# Altium schematic sheet PLL.SchDoc(PLL)
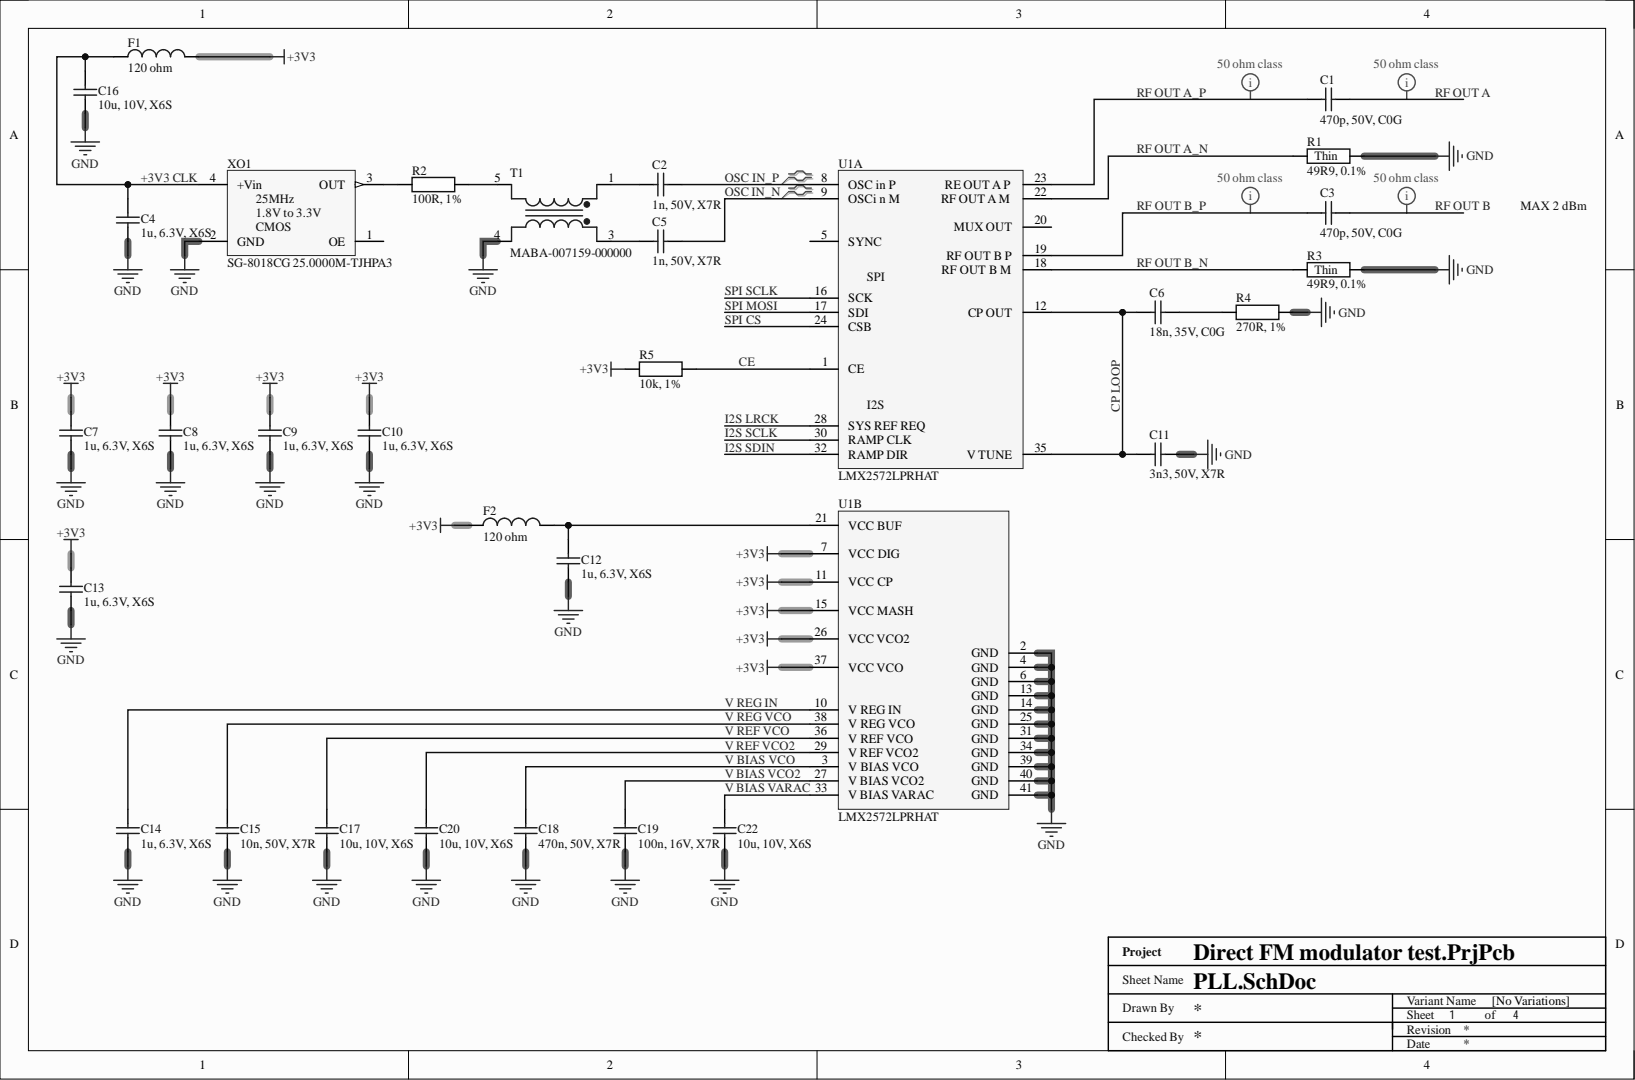
[diagram p0: PLL.SchDoc]

## Parts
C1  470p, 50V, C0G  [SMD0402]
C2  1n, 50V, X7R  [SMD0402]
C3  470p, 50V, C0G  [SMD0402]
C4  1u, 6.3V, X6S  [SMD0402]
C5  1n, 50V, X7R  [SMD0402]
C6  18n, 35V, C0G  [SMD0603]
C7  1u, 6.3V, X6S  [SMD0402]
C8  1u, 6.3V, X6S  [SMD0402]
C9  1u, 6.3V, X6S  [SMD0402]
C10  1u, 6.3V, X6S  [SMD0402]
C11  3n3, 50V, X7R  [SMD0603]
C12  1u, 6.3V, X6S  [SMD0402]
C13  1u, 6.3V, X6S  [SMD0402]
C14  1u, 6.3V, X6S  [SMD0402]
C15  10n, 50V, X7R  [SMD0402]
C16  10u, 10V, X6S  [SMD0603]
C17  10u, 10V, X6S  [SMD0603]
C18  470n, 50V, X7R  [SMD0603]
C19  100n, 16V, X7R  [SMD0402]
C20  10u, 10V, X6S  [SMD0603]
C22  10u, 10V, X6S  [SMD0603]
F1  120 ohm  [SMD0805 - R]
F2  120 ohm  [SMD0805 - R]
R1  49R9, 0.1%  [SMD0402 - R]
R2  100R, 1%  [SMD0402 - R]
R3  49R9, 0.1%  [SMD0402 - R]
R4  270R, 1%  [SMD0603 - R]
R5  10k, 1%  [SMD0603 - R]
T1  MABA-007159-000000  [MABA-007159-000000]
U1  LMX2572LPRHAT  [VQFN50P600X600X100_HS-41N]  (parts A,B)
XO1  SG-8018CG 25.0000M-TJHPA3  Freq: 25MHz  [XO 2.5x2.0mm]

## Nets
+3V3: C7.2 C8.2 C9.2 C10.2 C13.2 F1.2 F2.1 R5.2 U1.7(VCC_DIG) U1.11(VCC_CP) U1.15(VCC_MASH) U1.26(VCC_VCO2) U1.37(VCC_VCO)
GND: C4.1 C7.1 C8.1 C9.1 C10.1 C11.1 C12.1 C13.1 C14.1 C15.1 C16.1 C17.1 C18.1 C19.1 C20.1 C22.1 R1.2 R3.2 R4.1 T1.4 U1.2(GND) U1.4(GND) U1.6(GND) U1.13(GND) U1.14(GND) U1.25(GND) U1.31(GND) U1.34(GND) U1.39(GND) U1.40(GND) U1.41(GND) XO1.2(GND)
+3V3 CLK: C4.2 C16.2 F1.1 XO1.4(+Vin)
CE: R5.1 U1.1(CE)
CP LOOP: C6.1 C11.2 U1.12(CP_OUT) U1.35(V_TUNE)
I2S LRCK: U1.28(SYS_REF_REQ)
I2S SCLK: U1.30(RAMP_CLK)
I2S SDIN: U1.32(RAMP_DIR)
OSC IN_N: C5.2 U1.9(OSCi_n_M)
OSC IN_P: C2.2 U1.8(OSC_in_P)
RF OUT A: C1.2
RF OUT A_N: R1.1 U1.22(RF_OUT_A_M)
RF OUT A_P: C1.1 U1.23(RE_OUT_A_P)
RF OUT B: C3.2
RF OUT B_N: R3.1 U1.18(RF_OUT_B_M)
RF OUT B_P: C3.1 U1.19(RF_OUT_B_P)
SPI CS: U1.24(CSB)
SPI MOSI: U1.17(SDI)
SPI SCLK: U1.16(SCK)
V BIAS VARAC: C22.2 U1.33(V_BIAS_VARAC)
V BIAS VCO: C18.2 U1.3(V_BIAS_VCO)
V BIAS VCO2: C19.2 U1.27(V_BIAS_VCO2)
V REF VCO: C17.2 U1.36(V_REF_VCO)
V REF VCO2: C20.2 U1.29(V_REF_VCO2)
V REG IN: C14.2 U1.10(V_REG_IN)
V REG VCO: C15.2 U1.38(V_REG_VCO)
NetC2_1: C2.1 T1.1
NetC5_1: C5.1 T1.3
NetC6_2: C6.2 R4.2
NetC12_2: C12.2 F2.2 U1.21(VCC_BUF)
NetR2_1: R2.1 XO1.3(OUT)
NetR2_2: R2.2 T1.5

Not connected: U1 5(SYNC) 20(MUX_OUT); XO1 1(OE)

## Library data of the parts
C1 C3 = GCM1555C1H471FA16D: Cap Ceramic 470pF 50V C0G 1% Pad SMD 0402 125°C Automotive T/R; Manufacturer: Murata; Capacitance: 470p; Category: Ceramic Capacitors; Component Kind: Standard; Library Name: SMD0402Cap.IntLib; Manufacturer Part Number: GCM1555C1H471FA16D; Pricing 1: 1=0.12000, 10=0.06100, 50=0.06100, 100=0.04400, 1000=0.02700, 10000=0.01900 (USD); RoHS: Yes; Stock 1: 34290; Supplier 1: Mouser; Supplier 2: Farnell; Supplier Part Number 1: 81-GCM1555C1H471FA6D; Supplier Part Number 2: 3582659
C2 C5 = MC0402B102K500CT: MULTICOMP - MC0402B102K500CT - CAP, MLCC, X7R, 1NF, 50V, 0402; Capacitance Tolerance: ± 10%; Capacitor Terminals: SMD; Ceramic Capacitor Case: 0402 [1005 Metric]; Dielectric Characteristic: X7; External Depth: 1; External Length / Height: 0.5; No. of Pins: 2; Product Range: MC Series; SVHC: No SVHC (07-Jul-2017); Voltage Rating: 50; Automotive Qualification Standard: -; Capacitance: 1n; Capacitance Tolerance ±: ± 10%; Component Kind: Standard; ComponentLink1Description: http://www.farnell.com/datasheets/1901289.pdf; ComponentLink1URL: http://www.farnell.com/datasheets/1901289.pdf; External Width: 0.5; Library Name: SMD0402Cap.IntLib; Manufacturer: MULTICOMP; Manufacturer Part Number: MC0402B102K500CT; MSL: -; Operating Temperature Max: 125; Operating Temperature Min: -55; Operating Temperature Range: -55°C to +125°C<!--FANDB--><div class="features-and-benefits-container"><div class="features-and-benefits-desc-container"><span class="features-and-benefits-container-desc">SMD Multilayer Ceramic Capacitor, 0402 [1005 Metric], 1000 pF, 50 V, ± 10%, X7R, MC Series</span></div><div class="features-and-benefits-description"><span class="features-benefits-value">The Multicomp MC series is general purpose MLCC capacitors with X7R dielectric material which provides high electrical precision, stability and reliability. This MLCC capacitor consists of a conducting material and electrodes with high density and high efficiency ceramic condensers.</span></div><div class="features-and-benefits-list"><ul class="features-and-benefits-list-list"><li class="features-and-benefits-list-item">Small size with higher capacitance values</li><li class="features-and-benefits-list-item">0201, 0402, 0603, 0805, 1206, 1210, 1812 case sizes available</li><li class="features-and-benefits-list-item">Capacitance value ranging from 100pF to 47?F</li><li class="features-and-benefits-list-item">Capacitor with lead free termination (pure Tin)</li><li class="features-and-benefits-list-item">High electrical precision, stability and reliability</li><li class="features-and-benefits-list-item">Rated voltage of 6.3V, 10V, 16V, 25V, 50V, 100V</li><li class="features-and-benefits-list-item">Operating temperature of -55°C to +125°C</li><li class="features-and-benefits-list-item">Available in ±5%, ±10% and ±20; Packaging: Cut Tape; Pricing 1: 10=0,0036, 100=0,0032, 500=0,0029, 2500=0,0021, 5000=0,0018 (GBP); RoHS: YES; Stock 1: 83283; Supplier 1: Farnell; Supplier Part Number 1: 1758991
C4 C7-C10 C12-C14 = GRT155C80J105KE01D: MURATA - GRT155C80J105KE01D - CAP, MLCC, AECQ200, X6S, 1UF, 6.3V, 0402; Automotive Qualification Standard: AEC-Q200; Capacitance Tolerance: ± 10%; Ceramic Capacitor Case: 0402 [1005 Metric]; Dielectric Characteristic: X6; Product Range: GRT Series; SVHC: No SVHC (20-Jun-2016)<!--FANDB--><div class="features-and-benefits-container"><div class="features-and-benefits-description"><span class="features-benefits-value">The GRT155C80J105KE01D from Murata is a GRT series AEC-Q200 automotive-grade monolithic ceramic capacitor. This capacitor meets the AEC-Q200 reliability test standard requirements established by the Automotive Electronics Council. GRT series MLCC capacitors are classified into temperature compensating and high dielectric constant types depending on the change in electrostatic capacitance due to change in temperature. The temperature compensating capacitors feature a small rate of change in electrostatic capacitance as the temperature changes and are used for applications such as filters and high frequency circuit matching. The high dielectric constant capacitors use materials with a high dielectric constant and feature a large electrostatic capacitance. It is designed for use in car multimedia, car interior, car comfort application and general electronic equipment. It is not appropriate for use in applications critical to passenger safety and car driving function (ABS, AIRBAG etc).</span></div><div class="features-and-benefits-list"><ul class="features-and-benefits-list-list"><li class="features-and-benefits-list-item">AEC-Q200 automotive grade qualified</li><li class="features-and-benefits-list-item">Tin plated external electrodes, excellent solderability</li><li class="features-and-benefits-list-item">Capacitance value is 1µF</li><li class="features-and-benefits-list-item">Capacitance tolerance is ±10%</li><li class="features-and-benefits-list-item">Capacitor case size is 0402 [1005 Metric]</li><li class="features-and-benefits-list-item">X6S dielectric material</li><li class="features-and-benefits-list-item">Operating temperature range from -55°C to 105°C</li><li class="features-and-benefits-list-item">Voltage rating is 6.3; Voltage Rating: 6.3; Capacitance: 1u; Component Kind: Standard; ComponentLink1Description: http://www.farnell.com/datasheets/2165909.pdf; ComponentLink1URL: http://www.farnell.com/datasheets/2165909.pdf; Library Name: SMD0402Cap.IntLib; Manufacturer: MURATA; Manufacturer Part Number: GRT155C80J105KE01D; MSL: MSL 1 - Unlimited; Operating Temperature Max: 105; Operating Temperature Min: -55; Packaging: Cut Tape; Pricing 1: 10=0,0194, 100=0,0149, 500=0,0108, 2500=0,0079, 5000=0,0069, 10000=0,0062, 50000=0,0048, 100000=0,0047 (GBP); RoHS: YES; Stock 1: 19999; Supplier 1: Farnell; Supplier Part Number 1: 2672103
C6 = C1608C0G1V183J080AC: Cap Ceramic 0.018uF 35V C0G 5% Pad SMD 0603 125C T/R; Manufacturer: TDK; Capacitance: 18n; Case Code (Imperial): 0603; Case Code (Metric): 1608; Case/Package: 0603; Category: Ceramic Capacitors; Component Kind: Standard; ComponentLink1Description: https://product.tdk.com/info/en/catalog/spec/mlccspec_commercial_general_midvoltage_en.pdf?src-supplier=Digi-Key; ComponentLink1URL: https://product.tdk.com/info/en/catalog/spec/mlccspec_commercial_general_midvoltage_en.pdf?src-supplier=Digi-Key; Dielectric Material: Ceramic; Lead Free: Lead Free; Length: 0.063inch; Library Name: 0603Capacitors.SchLib; Manufacturer Part Number: C1608C0G1V183J080AC; Max Operating Temperature: 125°C; Min Operating Temperature: -55°C; Mount: Surface Mount; Packaging: Tape and Reel; Pricing 1: 1=0.37000, 10=0.16800, 50=0.16800, 100=0.14300, 1000=0.08800, 10000=0.06600 (USD); RoHS: Yes; RoHS Compliant: Yes; Stock 1: 3185; Supplier 1: Mouser; Supplier 2: Farnell; Supplier 3: Digi-Key; Supplier 4: Newark; Supplier Part Number 1: 810-C1608C0G1V183JAC; Supplier Part Number 2: 3416084; Supplier Part Number 3: 445-174558-1-ND; Supplier Part Number 4: 71AH3230; Thickness: 0.035inch; Tolerance: 5%; Voltage Rating: 35V; Voltage Rating (DC): 35V; Width: 0.031inch
C11 = 0603B332K500CT: WALSIN - 0603B332K500CT - CAPACITOR, MLCC, X7R, 3300PF, 50V, 0603; Capacitance Tolerance: ± 10%; Ceramic Capacitor Case: 0603 [1608 Metric]; Dielectric Characteristic: X7; Voltage Rating: 50; Automotive Qualification Standard: -; Capacitance: 3n3; Component Kind: Standard; ComponentLink1Description: http://www.farnell.com/datasheets/2340522.pdf; ComponentLink1URL: http://www.farnell.com/datasheets/2340522.pdf; Library Name: SMD0603Cap.IntLib; Manufacturer: WALSIN; Manufacturer Part Number: 0603B332K500CT; MSL: MSL 1 - Unlimited<!--FANDB--><div class="features-and-benefits-container"><div class="features-and-benefits-desc-container"><span class="features-and-benefits-container-desc">SMD Multilayer Ceramic Capacitor, 0603 [1608 Metric], 3300 pF, 50 V, ± 10%, X7R</span></div><div class="features-and-benefits-description"><span class="features-benefits-value">The general purpose series capacitors from Walsin are X7R dielectric multilayer ceramic chip capacitors (MLCC) that provides high electrical precision, stability and reliability. These MLCC capacitors consist of a conducting material and electrodes. The high density and high efficiency ceramic condensers are used to manufacture chip type miniaturized MLCC capacitors.</span></div><div class="features-and-benefits-list"><ul class="features-and-benefits-list-list"><li class="features-and-benefits-list-item">Capacitance values ranges from 100pF to 47?F</li><li class="features-and-benefits-list-item">EIA 0201, 0402, 0603, 0805, 1206, 1210, 1812 case sizes available</li><li class="features-and-benefits-list-item">Available voltage ratings are 6.3V, 10V, 16V, 25V, 35V, 50V, 100V</li><li class="features-and-benefits-list-item">Operating temperature range from -55°C to 125°C</li><li class="features-and-benefits-list-item">Available in ±5%, ±10%, ±20; Operating Temperature Max: 125; Operating Temperature Min: -55; Packaging: Cut Tape; Pricing 1: 10=0,0068, 100=0,0053, 500=0,0038, 1000=0,0031, 2000=0,0027 (GBP); Product Range: -; RoHS: YES; Stock 1: 10797; Supplier 1: Farnell; Supplier 2: Digi-Key; Supplier Part Number 1: 2496853; Supplier Part Number 2: BC1249TR-ND
C15 = MC0402B103K500CT: MULTICOMP - MC0402B103K500CT - CAP, MLCC, X7R, 0.01UF, 50V, 0402; Capacitance Tolerance: ± 10%; Ceramic Capacitor Case: 0402 [1005 Metric]; Dielectric Characteristic: X7; Product Range: MC Series; SVHC: No SVHC (12-Jan-2017)<!--FANDB--><div class="features-and-benefits-container"><div class="features-and-benefits-desc-container"><span class="features-and-benefits-container-desc">SMD Multilayer Ceramic Capacitor, 0402 [1005 Metric], 0.01 µF, 50 V, ± 10%, X7R, MC Series</span></div><div class="features-and-benefits-description"><span class="features-benefits-value">The Multicomp MC series are general purpose MLCC (Multilayer Ceramic Capacitor) capacitors with X7R dielectric material which provides high electrical precision, stability and reliability. These MLCC capacitors consists of a conducting material and electrodes with high density and high efficiency ceramic condensers.</span></div><div class="features-and-benefits-list"><ul class="features-and-benefits-list-list"><li class="features-and-benefits-list-item">Small size with higher capacitance values</li><li class="features-and-benefits-list-item">0201, 0402, 0603, 0805, 1206, 1210, 1812 case sizes available</li><li class="features-and-benefits-list-item">Capacitance value ranging from 100pF to 47?F</li><li class="features-and-benefits-list-item">Cu/Ni/Sn termination</li><li class="features-and-benefits-list-item">High electrical precision, stability and reliability</li><li class="features-and-benefits-list-item">Available in 6.3V, 10V, 16V, 25V, 50V and 100V rated voltages</li><li class="features-and-benefits-list-item">Operating temperature of -55°C to +125°C</li><li class="features-and-benefits-list-item">Available in ±5%, ±10% and ±20% tolerances</li><li class="features-and-benefits-list-item">Capacitance characteristic of ±15; Voltage Rating: 50; Automotive Qualification Standard: -; Capacitance: 10n; Component Kind: Standard; ComponentLink1Description: http://www.farnell.com/datasheets/2117933.pdf; ComponentLink1URL: http://www.farnell.com/datasheets/2117933.pdf; Library Name: SMD0402Cap.IntLib; Manufacturer: MULTICOMP; Manufacturer Part Number: MC0402B103K500CT; MSL: MSL 1 - Unlimited; Operating Temperature Max: 125; Operating Temperature Min: -55; Packaging: Cut Tape; Pricing 1: 10=0,0043, 100=0,0039, 500=0,0035, 2500=0,0021, 5000=0,0017 (GBP); RoHS: YES; Stock 1: 29387; Supplier 1: Farnell; Supplier Part Number 1: 2627340
C16 C17 C20 C22 = GRT188C81A106ME13D: Cap Ceramic 10uF 10V X6S 20% Pad SMD 0603 105C Automotive T/R; Manufacturer: Murata; Capacitance: 10uF; Case Code (Imperial): 0603; Case Code (Metric): 1608; Case/Package: 0603; Category: Ceramic Capacitors; Component Kind: Standard; ComponentLink1Description: http://search.murata.co.jp/Ceramy/image/img/A01X/G101/ENG/GRT188C81A106ME13-01.pdf?src-supplier=Digi-Key; ComponentLink1URL: http://search.murata.co.jp/Ceramy/image/img/A01X/G101/ENG/GRT188C81A106ME13-01.pdf?src-supplier=Digi-Key; Length: 0.063inch; Library Name: 0603Capacitors.SchLib; Manufacturer Part Number: GRT188C81A106ME13D; Max Operating Temperature: 105°C; Min Operating Temperature: -55°C; Mount: Surface Mount; Packaging: Tape and Reel; Pricing 1: 1=0.41000, 10=0.20100, 50=0.20100, 100=0.17200, 1000=0.10900, 10000=0.09700 (USD); Ratings: AEC-Q200; RoHS: No; Stock 1: 1862; Supplier 1: Mouser; Supplier 2: Farnell; Supplier 3: Digi-Key; Supplier Part Number 1: 81-GRT188C81A106ME3D; Supplier Part Number 2: 3582904; Supplier Part Number 3: 490-12283-6-ND; Thickness: 0.035inch; Tolerance: 20%; Voltage Rating: 10V; Width: 0.031inch
C18 = C1608X7R1H474K080AC: TDK - C1608X7R1H474K080AC - SMD Multilayer Ceramic Capacitor, 0.47 µF, 50 V, 0603 [1608 Metric], ± 10%, X7R, C Series TDK; Capacitance: 470n; Case Code (Imperial): 0603; Case Code (Metric): 1608; Case/Package: 0603; Category: Ceramic Capacitors; Component Kind: Standard; ComponentLink1Description: https://datasheet.ciiva.com/28693/1735394-28693461.pdf?src-supplier=Element14; ComponentLink1URL: https://datasheet.ciiva.com/28693/1735394-28693461.pdf?src-supplier=Element14; ComponentLink2Description: https://datasheet.ciiva.com/6290/tdkmlcccaprange-6290870.pdf?src-supplier=Digi-Key; ComponentLink2URL: https://datasheet.ciiva.com/6290/tdkmlcccaprange-6290870.pdf?src-supplier=Digi-Key; ComponentLink3Description: https://datasheet.ciiva.com/22718/1904212-22718022.pdf?src-supplier=Element14; ComponentLink3URL: https://datasheet.ciiva.com/22718/1904212-22718022.pdf?src-supplier=Element14; ComponentLink4Description: https://datasheet.ciiva.com/28451/1904212-28451367.pdf?src-supplier=Element14; ComponentLink4URL: https://datasheet.ciiva.com/28451/1904212-28451367.pdf?src-supplier=Element14; ComponentLink5Description: https://datasheet.ciiva.com/6290/tdkmlcccaprange-6290878.pdf?src-supplier=Digi-Key; ComponentLink5URL: https://datasheet.ciiva.com/6290/tdkmlcccaprange-6290878.pdf?src-supplier=Digi-Key; ComponentLink6Description: https://datasheet.ciiva.com/6290/tdkmlcccaprange-6290874.pdf?src-supplier=Digi-Key; ComponentLink6URL: https://datasheet.ciiva.com/6290/tdkmlcccaprange-6290874.pdf?src-supplier=Digi-Key; ComponentLink7Description: https://datasheet.ciiva.com/17487/e412-c-17487386.pdf?src-supplier=Avnet+Europe; ComponentLink7URL: https://datasheet.ciiva.com/17487/e412-c-17487386.pdf?src-supplier=Avnet+Europe; ComponentLink8Description: https://datasheet.ciiva.com/16427/e412-c-16427759.pdf?src-supplier=Future+Electronics; ComponentLink8URL: https://datasheet.ciiva.com/16427/e412-c-16427759.pdf?src-supplier=Future+Electronics; Composition: Ceramic; Depth: 0.8mm; Dielectric: X7R; Dielectric Material: Ceramic; Height: 0.8mm; Lead Free: Lead Free; Length: 1.6mm; Library Name: SMD0603Cap.IntLib; Manufacturer: TDK; Manufacturer Part Number: C1608X7R1H474K080AC; Max Operating Temperature: 125°C; Min Operating Temperature: -55°C; Mount: Surface Mount; Package Quantity: 4000; Packaging: Tape and Reel; Pricing 1: 1=0,28000, 10=0,14200, 100=0,09100, 500=0,07500, 1000=0,06100, 4000=0,04900 (USD); Radiation Hardening: No; REACH SVHC: No SVHC; RoHS: Yes; Stock 1: 163800; Supplier 1: Mouser; Supplier 2: Farnell; Supplier 3: Digi-Key; Supplier Part Number 1: 810-C1608X7R1H474K; Supplier Part Number 2: 2522012; Supplier Part Number 3: 445-5952-1-ND; Termination: SMD/SMT; Thickness: 0.037inch; Tolerance: 10%; Voltage: 50V; Voltage Rating: 50V; Voltage Rating (DC): 50V; Weight: 7.1E-05oz; Width: 0.031inch
C19 = MC0402B104K160CT: MULTICOMP - MC0402B104K160CT - CAP, MLCC, X7R, 100NF, 16V, 0402; Capacitance Tolerance: ± 10%; Capacitor Terminals: SMD; Ceramic Capacitor Case: 0402 [1005 Metric]; Dielectric Characteristic: X7; External Depth: 1; External Length / Height: 0.5; No. of Pins: 2; Product Range: MC Series; SVHC: No SVHC (07-Jul-2017); Voltage Rating: 16; Automotive Qualification Standard: -; Capacitance: 100n; Capacitance Tolerance ±: ± 10%; Component Kind: Standard; ComponentLink1Description: http://www.farnell.com/datasheets/1901289.pdf; ComponentLink1URL: http://www.farnell.com/datasheets/1901289.pdf; External Width: 0.5; Library Name: SMD0402Cap.IntLib; Manufacturer: MULTICOMP; Manufacturer Part Number: MC0402B104K160CT; MSL: -; Operating Temperature Max: 125; Operating Temperature Min: -55; Operating Temperature Range: -55°C to +125°C<!--FANDB--><div class="features-and-benefits-container"><div class="features-and-benefits-desc-container"><span class="features-and-benefits-container-desc">SMD Multilayer Ceramic Capacitor, 0402 [1005 Metric], 0.1 µF, 16 V, ± 10%, X7R, MC Series</span></div><div class="features-and-benefits-description"><span class="features-benefits-value">The Multicomp MC series is general purpose MLCC capacitors with X7R dielectric material which provides high electrical precision, stability and reliability. This MLCC capacitor consists of a conducting material and electrodes with high density and high efficiency ceramic condensers.</span></div><div class="features-and-benefits-list"><ul class="features-and-benefits-list-list"><li class="features-and-benefits-list-item">Small size with higher capacitance values</li><li class="features-and-benefits-list-item">0201, 0402, 0603, 0805, 1206, 1210, 1812 case sizes available</li><li class="features-and-benefits-list-item">Capacitance value ranging from 100pF to 47?F</li><li class="features-and-benefits-list-item">Capacitor with lead free termination (pure Tin)</li><li class="features-and-benefits-list-item">High electrical precision, stability and reliability</li><li class="features-and-benefits-list-item">Rated voltage of 6.3V, 10V, 16V, 25V, 50V, 100V</li><li class="features-and-benefits-list-item">Operating temperature of -55°C to +125°C</li><li class="features-and-benefits-list-item">Available in ±5%, ±10% and ±20; Packaging: Cut Tape; Pricing 1: 10=0,0043, 100=0,0039, 500=0,0035, 2500=0,0021, 5000=0,0017 (GBP); RoHS: YES; Stock 1: 315490; Supplier 1: Farnell; Supplier Part Number 1: 1758896
F1 F2 = 742792023: WURTH ELEKTRONIK - 742792023 - FERRITE BEAD, 0.03OHM, 3A, 0805; DC Current Rating: 3; DC Resistance Max: 0.03; Ferrite Case Style: 0805 [2012 Metric]; Ferrite Mounting: SMD; Impedance @ 100MHz: 120; Impedance Tolerance: ± 25%; Resistance: 0.03ohm<!--FANDB--><div class="features-and-benefits-container"><div class="features-and-benefits-description"><span class="features-benefits-value">The 742792023 is a SMD EMI Suppression Ferrite Bead with 0805 case and reliable Ni-Sn electrodes. It can be put directly on the printed circuit board. It offers excellent anti-EMI properties and low DC resistance. Placed very close next to the interference source even with smallest size 0402, maximal impedance at 1000; Automotive Qualification Standard: -; Component Kind: Standard; ComponentLink1Description: http://www.farnell.com/datasheets/1695980.pdf; ComponentLink1URL: http://www.farnell.com/datasheets/1695980.pdf; ComponentLink2Description: http://www.farnell.com/cad/1670054.zip; ComponentLink2URL: http://www.farnell.com/cad/1670054.zip; Library Name: Lib1.IntLib; Manufacturer: WURTH ELEKTRONIK; Manufacturer Part Number: 742792023; Packaging: Cut Tape; Pricing 1: 1=0,123, 50=0,112, 100=0,103, 500=0,0937, 1000=0,0877, 2000=0,0846 (GBP); Product Range: -; RoHS: YES; Stock 1: 4283; Supplier 1: Mouser; Supplier 2: Farnell; Supplier 3: Digi-Key; Supplier Part Number 1: 710-742792023; Supplier Part Number 2: 1635732; Supplier Part Number 3: 732-1613-1-ND
R1 R3 = AT0402BRD0749R9L: Thin Film Resistors - SMD 49.9 Ohms 0.1% Tol. AEC-Q200; Brand: Yageo; CNHTS: 8533211000; MXHTS: 85332101; Power Rating: 62.5 mW (1/16 W); TARIC: 8533210000; Temperature Coefficient: 25 PPM / C; Voltage Rating: 50 V; Case Code - in: 0402; Case Code - mm: 1005; Category: Thin Film Resistors - SMD; Component Kind: Standard; ComponentLink1Description: http://www.mouser.com/datasheet/2/447/PYu-AT_51_RoHS_L_5-1222714.pdf; ComponentLink1URL: http://www.mouser.com/datasheet/2/447/PYu-AT_51_RoHS_L_5-1222714.pdf; Diameter: -; Features: -; Height: 0.3 mm; HTS Code: 8533210030; Length: 1 mm; Library Name: SMD0402Res.IntLib; Manufacturer: Yageo; Manufacturer Part Number: AT0402BRD0749R9L; Maximum Operating Temperature: + 125 C; Minimum Operating Temperature: - 55 C; Minimum Order Quantity: 1; Mounting Style: PCB Mount; Operating Temperature Range: - 55 C to + 125 C; Package / Case: 0402 (1005 metric); Packaging: Cut Tape; Pricing 1: 1=0.594, 10=0.346, 100=0.139, 1000=0.106, 2500=0.081, 10000=0.079, 20000=0.077 (EUR); Product: Thin Film Resistors SMD; Product Type: Thin Film Resistors; Qualification: AEC-Q200; Reeling: True; Resistance: 49.9 Ohms; RoHS: RoHS Compliant; RoHS - Mouser: Y; Series: AT; Standard Pack Qty: 10000; Stock 1: 9662; Subcategory: Resistors; Supplier 1: Mouser; Supplier Part Number 1: 603-AT0402BRD0749R9L; Termination Style: SMD/SMT; Tolerance: 0.1 %; Type: High Precision High Stability Chip Resistors; Width: 0.5 mm
R2 = RC0402FR-07100RL: YAGEO - RC0402FR-07100RL - RES, 100R, 1%, 0.0625W, 0402, THICK FILM; Power Rating: 62.5; Product Range: RC Series; Reel Quantity: 10000<!--FANDB--><div class="features-and-benefits-container"><div class="features-and-benefits-desc-container"><span class="features-and-benefits-container-desc">SMD Chip Resistor, 0402 [1005 Metric], 100 ohm, RC Series, 50 V, Thick Film, 62.5 mW</span></div><div class="features-and-benefits-description"><span class="features-benefits-value">The Yageo RC_L series resistors are general purpose thick film chip resistors with lead free terminations made by thick film process. The resistance range includes E24 and E96 decade values from 1ohm to 100Mohm. These resistors are constructed on top of a high grade ceramic body.</span></div><div class="features-and-benefits-list"><ul class="features-and-benefits-list-list"><li class="features-and-benefits-list-item">EIA 0075, 0100, 0201, 0402, 0603, 0805, 1206, 1210, 1218, 2010, 2512 case sizes available</li><li class="features-and-benefits-list-item">Small chip sizes save PCB space</li><li class="features-and-benefits-list-item">Rated voltage range of 10V to 200V</li><li class="features-and-benefits-list-item">Maximum power rating of 2W</li><li class="features-and-benefits-list-item">Available in ±0.1%, ±0.5%, ±1% and ±5% tolerance</li><li class="features-and-benefits-list-item">High component and equipment reliability</li><li class="features-and-benefits-list-item">Forbidden materials are not used in products/production</li><li class="features-and-benefits-list-item">-55°C to 155°C temperature range for RC0402 to RC2512 and -55°C to 125°C for RC0075 to RC0201; Resistance: 100; Resistor Case Style: 0402 [1005 Metric]; SVHC: No SVHC (27-Jun-2018); Temperature Coefficient: ± 100; Voltage Rating: 50; Automotive Qualification Standard: -; Component Kind: Standard; ComponentLink1Description: http://www.farnell.com/datasheets/2167052.pdf; ComponentLink1URL: http://www.farnell.com/datasheets/2167052.pdf; Library Name: SMD0402Res.IntLib; Manufacturer: YAGEO; Manufacturer Part Number: RC0402FR-07100RL; MSL: MSL 1 - Unlimited; Operating Temperature Max: 155; Operating Temperature Min: -55; Pricing 1: 10=0.385, 100=0.38, 500=0.285, 2500=0.144, 5000=0.121 (EUR); Resistance Tolerance: ± 1%; Resistor Element Material: Thick Film; RoHS: YES; Stock 1: 260492; Supplier 1: Farnell; Supplier 2: Mouser; Supplier Part Number 1: 9239111; Supplier Part Number 2: 603-RC0402FR-07100RL
R4 = WR06X2700FTL: WALSIN - WR06X2700FTL - RES, THICK FILM, 270R, 1%, 75V, 0603; Power Rating: 100; Product Range: WR06 Series; Resistor Case Style: 0603 [1608 Metric]; Temperature Coefficient: ± 100; Voltage Rating: 75; Automotive Qualification Standard: -; Component Kind: Standard; ComponentLink1Description: http://www.farnell.com/datasheets/1960319.pdf; ComponentLink1URL: http://www.farnell.com/datasheets/1960319.pdf; Library Name: SMD0603Res.IntLib; Manufacturer: WALSIN; Manufacturer Part Number: WR06X2700FTL; MSL: MSL 2 - 1 year<!--FANDB--><div class="features-and-benefits-container"><div class="features-and-benefits-desc-container"><span class="features-and-benefits-container-desc">SMD Chip Resistor, 270 ohm, 75 V, 0603 [1608 Metric], 100 mW, ± 1%, WR06 Series</span></div><div class="features-and-benefits-description"><span class="features-benefits-value">The WR06 series resistors from Walsin are general purpose thick film chip resistors. These resistors are constructed in a high grade ceramic body (aluminium oxide).</span></div><div class="features-and-benefits-list"><ul class="features-and-benefits-list-list"><li class="features-and-benefits-list-item">Resistance range includes E24 and E96 decade values from 1ohm to 10Mohm</li><li class="features-and-benefits-list-item">EIA 0603 case size</li><li class="features-and-benefits-list-item">Available in ?±100ppm/°C and -200ppm/°C to +400ppm/°C TCR values</li><li class="features-and-benefits-list-item">Available in ±1% and ±5% tolerance</li><li class="features-and-benefits-list-item">High reliability and stability</li><li class="features-and-benefits-list-item">Higher component and equipment reliability</li><li class="features-and-benefits-list-item">Lower assembly costs</li><li class="features-and-benefits-list-item">Reduced size of final equipment</li><li class="features-and-benefits-list-item">Continuous working voltage is 75V and rated power is 100; Packaging: Cut Tape; Pricing 1: 10=0.0132, 100=0.0044, 500=0.0027, 1000=0.0019, 2500=0.0014 (GBP); Resistance: 270R; Resistance Tolerance: ± 1%; Resistor Element Material: Thick Film; RoHS: YES; Stock 1: 6379; Supplier 1: Farnell; Supplier Part Number 1: 2502438
R5 = RC0603FR-0710KL: YAGEO (PHYCOMP) - RC0603FR-0710KL - RES, THICK FILM, 10K, 1%, 0.1W, 0603; Power Rating: 100; Product Range: RC Series; Resistor Case Style: 0603 [1608 Metric]; SVHC: No SVHC (20-Jun-2016); Temperature Coefficient: ± 100; Voltage Rating: 50; Automotive Qualification Standard: -; Component Kind: Standard; ComponentLink1Description: http://past.yageo.com/pdf/PYu-R_Packing_6.pdf; ComponentLink1URL: http://past.yageo.com/pdf/PYu-R_Packing_6.pdf; ComponentLink2Description: http://www.farnell.com/datasheets/2167052.pdf; ComponentLink2URL: http://www.farnell.com/datasheets/2167052.pdf; Library Name: SMD0603Res.IntLib; Manufacturer: YAGEO (PHYCOMP); Manufacturer Part Number: RC0603FR-0710KL; MSL: MSL 1 - Unlimited<!--FANDB--><div class="features-and-benefits-container"><div class="features-and-benefits-desc-container"><span class="features-and-benefits-container-desc">SMD Chip Resistor, Thick Film, 10 kohm, 50 V, 0603 [1608 Metric], 100 mW, ± 1; Packaging: Tape & Reel; Pricing 1: 5000=0.0025, 25000=0.0014, 50000=0.0013 (GBP); Resistance: 10k; Resistance Tolerance: ± 1%; Resistor Element Material: Thick Film; RoHS: YES; Stock 1: 9995; Supplier 1: Mouser; Supplier 2: Farnell; Supplier 3: Digi-Key; Supplier Part Number 1: 603-RC0603FR-0710KL; Supplier Part Number 2: 2421850; Supplier Part Number 3: 311-10.0KHRCT-ND
T1 = MABA-007159-000000: Transformator 4.5-3000MHz IL 2dB Impedance Ratio 1:1; Manufacturer: M/A-Com Technology Solutions; Frequency Range: 4.5MHz ~ 3GHz; Insertion Loss (Max): 3.5dB; Category: RF/IF and RFID; Component Kind: Standard; ComponentLink1Description: http://cdn.macom.com/datasheets/MABA-007159-000000.pdf; ComponentLink1URL: http://cdn.macom.com/datasheets/MABA-007159-000000.pdf; Datasheet: http://www.mouser.com/ds/2/249/MABA-007159-000000-318068.pdf; Impedance - Unbalanced/Balanced: 1:1; Library Name: RF components.IntLib; Manufacturer Part Number: MABA-007159-000000; Mounting Type: Surface Mount; Name: MABA-007159-000000; Package / Case: 6-SMD (5 Leads), Flat Lead; Packaging: Cut Tape (CT); Phase Difference: -; Pricing 2: 1=1.72, 10=1.379, 25=1.1208, 50=1.092, 100=1.0633, 250=1.03456, 500=1.02592, 1000=0.90811 (USD); Return Loss (Min): -; RoHS: RoHS Compliant; Stock 2: 26395; Supplier 1: Mouser; Supplier 2: Digi-Key; Supplier Part Number 1: 937-MABA007159000000; Supplier Part Number 2: 1465-1302-1-ND
U1 = LMX2572LPRHAT: 2-GHz Low Power Wideband RF Synthesizer With FSK Modulation 40-VQFN -40 to 85; Manufacturer: Texas Instruments; Input: Clock; Max Frequency: 2GHz; Number of Circuits: 1; PLL: Yes; Case/Package: VQFN; Category: Clock Generators, PLLs, Frequency Synthesizers; Component Kind: Standard; ComponentLink1Description: http://www.ti.com/general/docs/suppproductinfo.tsp?distId=10&amp;gotoUrl=http%3A%2F%2Fwww.ti.com%2Flit%2Fgpn%2Flmx2572lp&src-supplier=Digi-Key; ComponentLink1URL: http://www.ti.com/general/docs/suppproductinfo.tsp?distId=10&amp;gotoUrl=http%3A%2F%2Fwww.ti.com%2Flit%2Fgpn%2Flmx2572lp&src-supplier=Digi-Key; ComponentLink2Description: http://www.ti.com/lit/ds/symlink/lmx2572lp.pdf?src-supplier=Digi-Key; ComponentLink2URL: http://www.ti.com/lit/ds/symlink/lmx2572lp.pdf?src-supplier=Digi-Key; Library Name: RF components.IntLib; Manufacturer Part Number: LMX2572LPRHAT; Max Operating Temperature: 85°C; Min Operating Temperature: -40°C; Mount: Surface Mount; Packaging: Tape and Reel; Pricing 1: 1=14.10000, 10=12.96000, 50=12.43000, 100=10.95000, 1000=10.40000, 10000=10.40000 (USD); RoHS: Yes; RoHS Compliant: Yes; Stock 1: 77; Supplier 1: Mouser; Supplier 2: Arrow Electronics; Supplier 3: Digi-Key; Supplier Part Number 1: 595-LMX2572LPRHAT; Supplier Part Number 2: LMX2572LPRHAT; Supplier Part Number 3: 296-51375-1-ND
XO1 = SG-8018CG 25.0000M-TJHPA3: Oscillator XO 25MHz ±50ppm 15pF CMOS 55% 1.8V/2.5V/3.3V 4-Pin SMD T/R; Manufacturer: Epson; Component Kind: Standard; ComponentLink1Description: http://datasheet.octopart.com/SG-8018CG-25.0000M-TJHPA3-Epson-datasheet-137868109.pdf?src-supplier=Future+Electronics; ComponentLink1URL: http://datasheet.octopart.com/SG-8018CG-25.0000M-TJHPA3-Epson-datasheet-137868109.pdf?src-supplier=Future+Electronics; Library Name: Xtal_Osc.IntLib; Manufacturer Part Number: SG-8018CG 25.0000M-TJHPA3; Pricing 1: 1=1,11000, 10=1,03000, 100=0,88700, 250=0,88700, 500=0,78900, 1000=0,72700 (USD); Stock 1: 221; Supplier 1: Mouser; Supplier 2: Arrow; Supplier 3: Future Electronics; Supplier Part Number 1: 732-CG25M-TJHPA3; Supplier Part Number 2: SG-8018CG 25.0000M-TJHPA3; Supplier Part Number 3: SG-8018CG 25.0000M-TJHPA3-REEL
